# Altium schematic sheet 01-Power.SchDoc(Power)
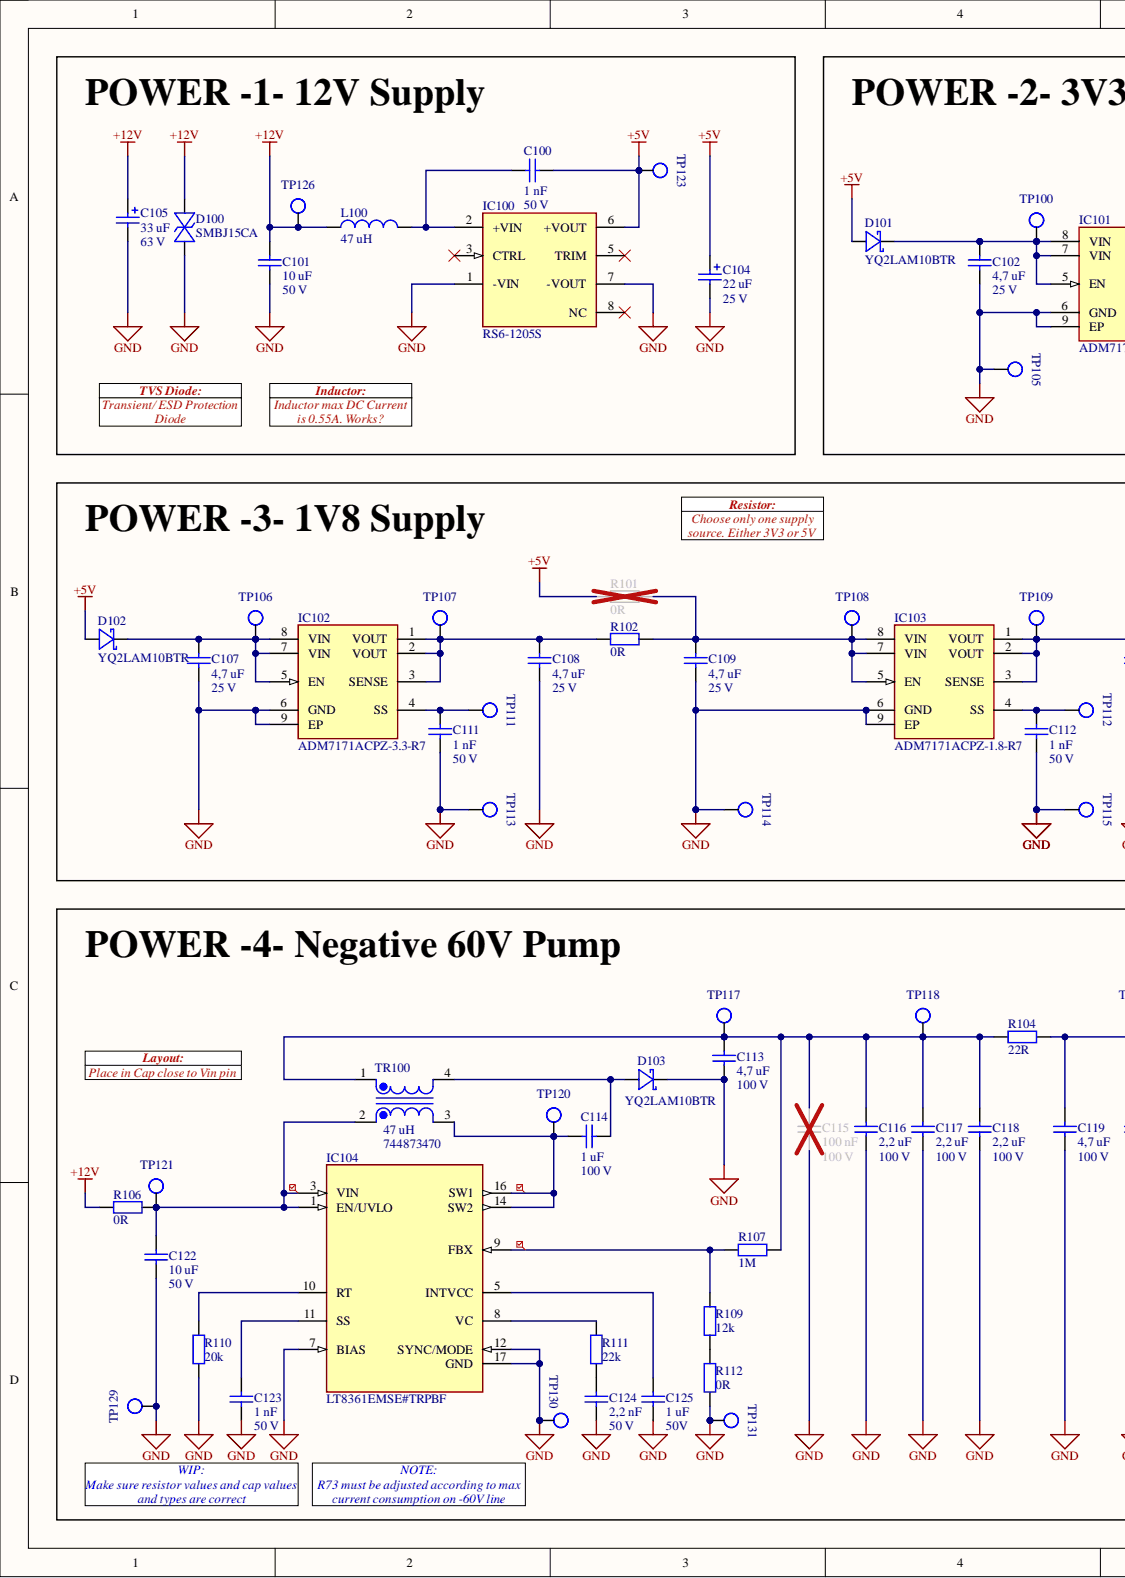
[diagram p0: 01-Power.SchDoc, left]
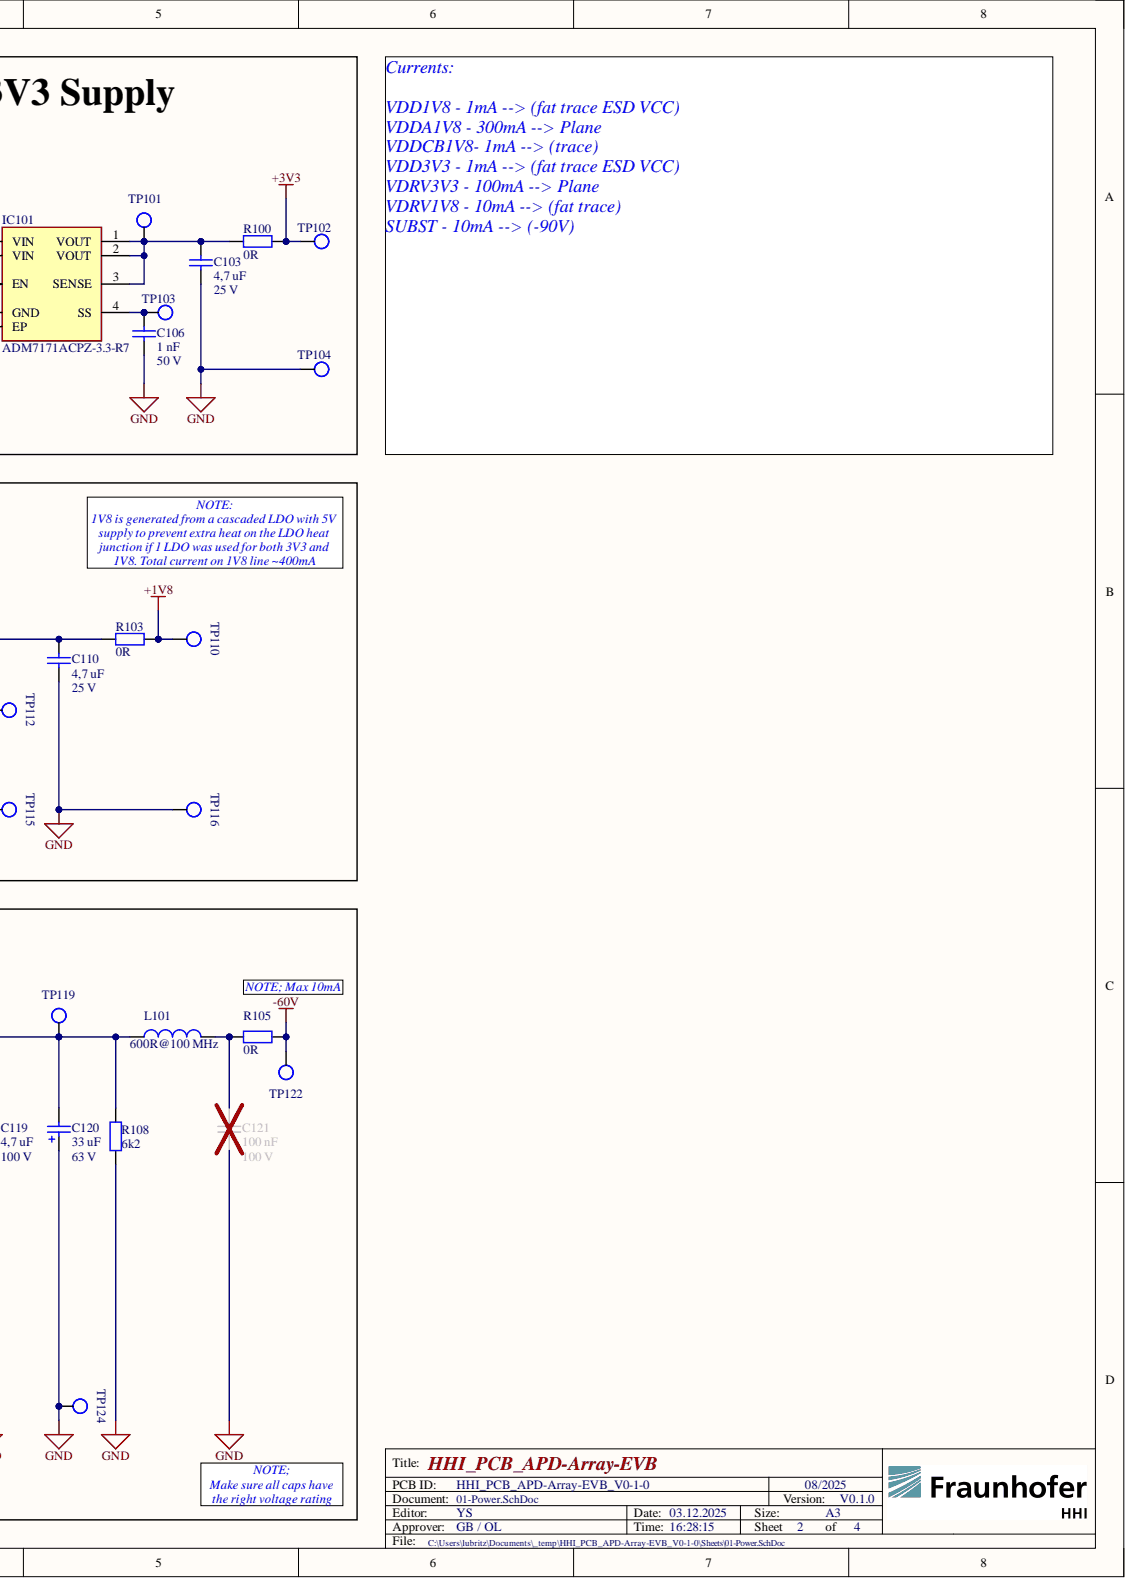
[diagram p1: 01-Power.SchDoc, right]

## Parts
C100  1 nF  [CAP_CAPC1608_0603_N]
C101  10 uF  Voltage: 50 V  [CAP_CAPC2012_0805_N]
C102  4,7 uF  Voltage: 25 V  [CAP_CAPC1608_0603_N]
C103  4,7 uF  Voltage: 25 V  [CAP_CAPC1608_0603_N]
C104  22 uF  Voltage: 25 V  [CAP_Elko_TypC_5,3x5,3x5,8]
C105  33 uF  [CAP_Elko_TypF_8,3x8,3x10,2]
C106  1 nF  Voltage: 50 V  [CAP_CAPC1608_0603_N]
C107  4,7 uF  Voltage: 25 V  [CAP_CAPC1608_0603_N]
C108  4,7 uF  Voltage: 25 V  [CAP_CAPC1608_0603_N]
C109  4,7 uF  Voltage: 25 V  [CAP_CAPC1608_0603_N]
C110  4,7 uF  Voltage: 25 V  [CAP_CAPC1608_0603_N]
C111  1 nF  Voltage: 50 V  [CAP_CAPC1608_0603_N]
C112  1 nF  Voltage: 50 V  [CAP_CAPC1608_0603_N]
C113  4,7 uF  Voltage: 100 V  [CAP_CAPC3216_1206_N]
C114  1 uF  Voltage: 100 V  [CAP_CAPC4532_1812]
C115  100 nF  [CAP_CAPC1608_0603_N]
C116  2,2 uF  Voltage: 100 V  [CAP_CAPC3225_1210_N]
C117  2,2 uF  Voltage: 100 V  [CAP_CAPC3225_1210_N]
C118  2,2 uF  Voltage: 100 V  [CAP_CAPC3225_1210_N]
C119  4,7 uF  Voltage: 100 V  [CAP_CAPC3216_1206_N]
C120  33 uF  Voltage: 63 V  [CAP_Elko_TypF_8,3x8,3x10,2]
C121  100 nF  Voltage: 100 V  [CAP_CAPC1608_0603_N]
C122  10 uF  Voltage: 50 V  [CAP_CAPC2012_0805_N]
C123  1 nF  Voltage: 50 V  [CAP_CAPC1608_0603_N]
C124  2,2 nF  [CAP_CAPC1608_0603_N]
C125  1 uF  Voltage: 50V  [CAP_CAPC2012_0805_N]
D100  SMBJ15CA  [DIO_DO-214AA_SMB_DIOM4336X24_N]
D101  YQ2LAM10BTR  [DIO_SOD-128_SODFL470X95-2N]
D102  YQ2LAM10BTR  [DIO_SOD-128_SODFL470X95-2N]
D103  YQ2LAM10BTR  [DIO_SOD-128_SODFL470X95-2N]
IC100  RS6-1205S  OutputVoltage: 5 V  [IC_THT_RS6-1205S]
IC101  ADM7171ACPZ-3.3-R7  [IC_LFCSP-8_QFN50P300X300X80_HS-9N]
IC102  ADM7171ACPZ-3.3-R7  [IC_LFCSP-8_QFN50P300X300X80_HS-9N]
IC103  ADM7171ACPZ-1.8-R7  [IC_LFCSP-8_QFN50P300X300X80_HS-9N]
IC104  LT8361EMSE#TRPBF  [IC_MSOP-16_SOP50P490X110-17N_LT8361EMSE#TRPBF]
L100  47 uH  [IND_WE-MAPI_3015]
L101  600R@100 MHz  [IND_INDC1608_0603_N]
R100  0R  [RES_RESC3216_1206_N]
R101  0R  [RES_RESC3216_1206_N]
R102  0R  [RES_RESC3216_1206_N]
R103  0R  [RES_RESC3216_1206_N]
R104  22R  [RES_RESC1608_0603_N]
R105  0R  [RES_RESC1608_0603_N]
R106  0R  [RES_RESC1608_0603_N]
R107  1M  [RES_RESC1608_0603_N]
R108  6k2  [RES_RESC2012_0805_N]
R109  12k  [RES_RESC1608_0603_N]
R110  20k  [RES_RESC1608_0603_N]
R111  22k  [RES_RESC1608_0603_N]
R112  0R  [RES_RESC1608_0603_N]
TP100  0,8 mm / 1,4 mm  [MISC_TP_THT_RD_0,8MM_1,4MM]  (value not drawn)  (no BOM)
TP101  0,8 mm / 1,4 mm  [MISC_TP_THT_RD_0,8MM_1,4MM]  (value not drawn)  (no BOM)
TP102  0,8 mm / 1,4 mm  [MISC_TP_THT_RD_0,8MM_1,4MM]  (value not drawn)  (no BOM)
TP103  0,8 mm / 1,4 mm  [MISC_TP_THT_RD_0,8MM_1,4MM]  (value not drawn)  (no BOM)
TP104  0,8 mm / 1,4 mm  [MISC_TP_THT_RD_0,8MM_1,4MM]  (value not drawn)  (no BOM)
TP105  0,8 mm / 1,4 mm  [MISC_TP_THT_RD_0,8MM_1,4MM]  (value not drawn)  (no BOM)
TP106  0,8 mm / 1,4 mm  [MISC_TP_THT_RD_0,8MM_1,4MM]  (value not drawn)  (no BOM)
TP107  0,8 mm / 1,4 mm  [MISC_TP_THT_RD_0,8MM_1,4MM]  (value not drawn)  (no BOM)
TP108  0,8 mm / 1,4 mm  [MISC_TP_THT_RD_0,8MM_1,4MM]  (value not drawn)  (no BOM)
TP109  0,8 mm / 1,4 mm  [MISC_TP_THT_RD_0,8MM_1,4MM]  (value not drawn)  (no BOM)
TP110  0,8 mm / 1,4 mm  [MISC_TP_THT_RD_0,8MM_1,4MM]  (value not drawn)  (no BOM)
TP111  0,8 mm / 1,4 mm  [MISC_TP_THT_RD_0,8MM_1,4MM]  (value not drawn)  (no BOM)
TP112  0,8 mm / 1,4 mm  [MISC_TP_THT_RD_0,8MM_1,4MM]  (value not drawn)  (no BOM)
TP113  0,8 mm / 1,4 mm  [MISC_TP_THT_RD_0,8MM_1,4MM]  (value not drawn)  (no BOM)
TP114  0,8 mm / 1,4 mm  [MISC_TP_THT_RD_0,8MM_1,4MM]  (value not drawn)  (no BOM)
TP115  0,8 mm / 1,4 mm  [MISC_TP_THT_RD_0,8MM_1,4MM]  (value not drawn)  (no BOM)
TP116  0,8 mm / 1,4 mm  [MISC_TP_THT_RD_0,8MM_1,4MM]  (value not drawn)  (no BOM)
TP117  0,8 mm / 1,4 mm  [MISC_TP_THT_RD_0,8MM_1,4MM]  (value not drawn)  (no BOM)
TP118  0,8 mm / 1,4 mm  [MISC_TP_THT_RD_0,8MM_1,4MM]  (value not drawn)  (no BOM)
TP119  0,8 mm / 1,4 mm  [MISC_TP_THT_RD_0,8MM_1,4MM]  (value not drawn)  (no BOM)
TP120  0,8 mm / 1,4 mm  [MISC_TP_THT_RD_0,8MM_1,4MM]  (value not drawn)  (no BOM)
TP121  0,8 mm / 1,4 mm  [MISC_TP_THT_RD_0,8MM_1,4MM]  (value not drawn)  (no BOM)
TP122  0,8 mm / 1,4 mm  [MISC_TP_THT_RD_0,8MM_1,4MM]  (value not drawn)  (no BOM)
TP123  0,8 mm / 1,4 mm  [MISC_TP_THT_RD_0,8MM_1,4MM]  (value not drawn)  (no BOM)
TP124  0,8 mm / 1,4 mm  [MISC_TP_THT_RD_0,8MM_1,4MM]  (value not drawn)  (no BOM)
TP126  0,8 mm / 1,4 mm  [MISC_TP_THT_RD_0,8MM_1,4MM]  (value not drawn)  (no BOM)
TP129  0,8 mm / 1,4 mm  [MISC_TP_THT_RD_0,8MM_1,4MM]  (value not drawn)  (no BOM)
TP130  0,8 mm / 1,4 mm  [MISC_TP_THT_RD_0,8MM_1,4MM]  (value not drawn)  (no BOM)
TP131  0,8 mm / 1,4 mm  [MISC_TP_THT_RD_0,8MM_1,4MM]  (value not drawn)  (no BOM)
TR100  47 uH  MfrNo: 744873470  [IND_WE-DD_1280]

## Nets
+1V8: R103.2 TP110.1
+3V3: R100.2 TP102.1
+5V: C100.2 C104.1 D101.2 D102.2 IC100.6(+VOUT) R101.1 TP123.1
+12V: C101.1 C105.1 D100.2 L100.1 R106.1 TP126.1
-60V: R105.2 TP122.1
GND: C101.2 C102.2 C103.2 C104.2 C105.2 C106.2 C107.2 C108.2 C109.2 C110.2 C111.2 C112.2 C113.2 C115.2 C116.2 C117.2 C118.2 C119.2 C120.1 C121.2 C122.2 C123.2 C124.2 C125.2 D100.1 D103.1 IC100.1(-VIN) IC100.7(-VOUT) IC101.6(GND) IC101.9(EP) IC102.6(GND) IC102.9(EP) IC103.6(GND) IC103.9(EP) IC104.7(BIAS) IC104.12(SYNC/MODE) IC104.17(GND) R108.1 R110.1 R112.1 TP104.1 TP105.1 TP113.1 TP114.1 TP115.1 TP116.1 TP124.1 TP129.1 TP130.1 TP131.1
NetC100_1: C100.1 IC100.2(+VIN) L100.2
NetC102_1: C102.1 D101.1 IC101.5(EN) IC101.7(VIN) IC101.8(VIN) TP100.1
NetC103_1: C103.1 IC101.1(VOUT) IC101.2(VOUT) IC101.3(SENSE) R100.1 TP101.1
NetC106_1: C106.1 IC101.4(SS) TP103.1
NetC107_1: C107.1 D102.1 IC102.5(EN) IC102.7(VIN) IC102.8(VIN) TP106.1
NetC108_1: C108.1 IC102.1(VOUT) IC102.2(VOUT) IC102.3(SENSE) R102.1 TP107.1
NetC109_1: C109.1 IC103.5(EN) IC103.7(VIN) IC103.8(VIN) R101.2 R102.2 TP108.1
NetC110_1: C110.1 IC103.1(VOUT) IC103.2(VOUT) IC103.3(SENSE) R103.1 TP109.1
NetC111_1: C111.1 IC102.4(SS) TP111.1
NetC112_1: C112.1 IC103.4(SS) TP112.1
NetC113_1: C113.1 C115.1 C116.1 C117.1 C118.1 R104.1 R107.2 TP117.1 TP118.1 TR100.1
NetC114_1: C114.1 D103.2 TR100.4
NetC114_2: C114.2 IC104.14(SW2) IC104.16(SW1) TP120.1 TR100.3
NetC119_1: C119.1 C120.2 L101.1 R104.2 R108.2 TP119.1
NetC121_1: C121.1 L101.2 R105.1
NetC122_1: C122.1 IC104.1(EN/UVLO) IC104.3(VIN) R106.2 TP121.1 TR100.2
NetC123_1: C123.1 IC104.11(SS)
NetC124_1: C124.1 R111.1
NetC125_1: C125.1 IC104.5(INTVCC)
NetIC100_3: IC100.3(CTRL)
NetIC100_5: IC100.5(TRIM)
NetIC100_8: IC100.8(NC)
NetIC104_8: IC104.8(VC) R111.2
NetIC104_9: IC104.9(FBX) R107.1 R109.2
NetIC104_10: IC104.10(RT) R110.2
NetR109_1: R109.1 R112.2

## Library data of the parts
C100 = CAP0058: CAP / 1 nF / 0603 / 50 V / X7R / 10%; Mfr: Würth Elektronik; MfrNo: 885012206083; Database Table Name: capacitor$; Dis: Mouser; DisNo: 710-885012206083; Editor: OL; ProvidedBy: EMS; Type: MLCC; Voltage: 50 V; Component Kind: Standard; DesignItemID: CAP0058; Dielectric: X7R; ESR: -; Mfr-Link: https://www.we-online.com/de/components/products/WCAP-CSGP-50VDC; Package: 0603; Pins: 2; Status: Done; TempHigh: 125 °C; TempLow: -55 °C; Tolerance: 10%; Value: 1 nF; Library Name: Altium-Lib-HHI.DbLib
C101 C122 = CAP0067: CAP / 10 uF / 0805 / 50 V / X5R / 10%; Mfr: Murata; MfrNo: GRM21BR61H106KE43L; Database Table Name: capacitor$; Dis: Mouser; DisNo: 81-GRM21BR61H106KE3L; Editor: OL; ProvidedBy: EMS; Type: MLCC; Component Kind: Standard; DesignItemID: CAP0067; Dielectric: X5R; ESR: -; Mfr-Link: https://www.murata.com/en-eu/products/productdetail?partno=GRM21BR61H106KE43%23; Package: 0805; Pins: 2; Status: Done; TempHigh: 85 °C; TempLow: -55 °C; Tolerance: 10%; Value: 10 uF; Library Name: Altium-Lib-HHI.DbLib
C102 C103 C107-C110 = CAP0043: CAP / 4,7 uF / 0603 / 25 V / X5R / 10%; Mfr: Murata; MfrNo: GRM188R61E475KE11D; Database Table Name: capacitor$; Dis: Mouser; DisNo: 81-GRM188R61E475KE1D; Editor: OL; ProvidedBy: EMS; Type: MLCC; Component Kind: Standard; DesignItemID: CAP0043; Dielectric: X5R; ESR: -; Mfr-Link: https://www.murata.com/en-eu/products/productdetail?partno=GRM188R61E475KE11%23; Package: 0603; Pins: 2; Status: Done; TempHigh: 85 °C; TempLow: -55 °C; Tolerance: 10%; Value: 4,7 uF; Library Name: Altium-Lib-HHI.DbLib
C104 = CAP0112: CAP / 22 uF / 5,3x5,3x5,8 / 25 V / - / 20%; Mfr: Panasonic; MfrNo: EEEFP1E220AR; Database Table Name: capacitor$; Dis: Mouser; DisNo: 667-EEE-FP1E220AR; Editor: OL; ESR: 360 mR; ProvidedBy: EMS; Type: Al-Elko; Component Kind: Standard; DesignItemID: CAP0112; Dielectric: -; Mfr-Link: https://industrial.panasonic.com/ww/products/pt/aluminum-cap-smd/models/EEEFP1E220AR; Package: 5,3x5,3x5,8; Pins: 2; Status: Done; TempHigh: 105 °C; TempLow: -55 °C; Tolerance: 20%; Value: 22 uF; Library Name: Altium-Lib-HHI.DbLib
C105 = CAP0038: CAP / 33 uF / 8,3x8,3x10,2 / 63 V / - / 20%; Mfr: Panasonic; MfrNo: EEEFK1J330P; Database Table Name: capacitor$; Dis: Mouser; DisNo: 67-EEE-FK1J330P; Editor: OL; ESR: 650 mR; ProvidedBy: EMS; Type: Al-Elko; Voltage: 63 V; Component Kind: Standard; DesignItemID: CAP0038; Dielectric: -; Mfr-Link: https://industrial.panasonic.com/ww/products/pt/aluminum-cap-smd/models/EEEFK1J330P; Package: 8,3x8,3x10,2; Pins: 2; Status: Done; TempHigh: 105 °C; TempLow: -55 °C; Tolerance: 20%; Value: 33 uF; Library Name: Altium-Lib-HHI.DbLib
C106 C111 C112 C123 = CAP0058: CAP / 1 nF / 0603 / 50 V / X7R / 10%; Mfr: Würth Elektronik; MfrNo: 885012206083; Database Table Name: capacitor$; Dis: Mouser; DisNo: 710-885012206083; Editor: OL; ProvidedBy: EMS; Type: MLCC; Component Kind: Standard; DesignItemID: CAP0058; Dielectric: X7R; ESR: -; Mfr-Link: https://www.we-online.com/de/components/products/WCAP-CSGP-50VDC; Package: 0603; Pins: 2; Status: Done; TempHigh: 125 °C; TempLow: -55 °C; Tolerance: 10%; Value: 1 nF; Library Name: Altium-Lib-HHI.DbLib
C113 C119 = CAP0118: CAP / 4,7 uF / 1206 / 100 V / X7R / 10%; Mfr: TDK; MfrNo: C3216X7R2A475K160AC; Database Table Name: capacitor$; Dis: Mouser; DisNo: 810-C3216X7R2475K160; Editor: OL; ProvidedBy: EMS; Type: MLCC; Component Kind: Standard; DesignItemID: CAP0118; Dielectric: X7R; ESR: -; Mfr-Link: https://product.tdk.com/de/search/capacitor/ceramic/mlcc/info?part_no=C3216X7R2A475K160AC; Package: 1206; Pins: 2; Status: Done; TempHigh: 125 °C; TempLow: -55 °C; Tolerance: 10%; Value: 4,7 uF; Library Name: Altium-Lib-HHI.DbLib
C114 = CAP0065: CAP / 1 uF / 1812 / 100 V / X7R / 10%; Mfr: Kyocera AVX; MfrNo: 18121C105KAT2A; Database Table Name: capacitor$; Dis: Mouser; DisNo: 581-18121C105K; Editor: OL; ProvidedBy: EMS; Type: MLCC; Component Kind: Standard; DesignItemID: CAP0065; Dielectric: X7R; ESR: -; Mfr-Link: https://www.kyocera-avx.com/products/ceramic-capacitors/surface-mount/x7r-dielectric/; Package: 1812; Pins: 2; Status: Done; TempHigh: 125 °C; TempLow: -55 °C; Tolerance: 10%; Value: 1 uF; Library Name: Altium-Lib-HHI.DbLib
C115 = CAP0004: CAP / 100 nF / 0603 / 100 V / X7R / 10%; Mfr: Würth Elektronik; MfrNo: 885012206120; Database Table Name: capacitor$; Dis: Mouser; DisNo: 710-885012206120; Editor: OL; ProvidedBy: EMS; Type: MLCC; Voltage: 100 V; Component Kind: Standard; DesignItemID: CAP0004; Dielectric: X7R; ESR: -; Mfr-Link: https://www.we-online.com/de/components/products/WCAP-CSGP-100VDC; Package: 0603; Pins: 2; Status: Done; TempHigh: 125 °C; TempLow: -55 °C; Tolerance: 10%; Value: 100 nF; Library Name: Altium-Lib-HHI.DbLib
C116-C118 = CAP0035: CAP / 2,2 uF / 1210 / 100 V / X7R / 10%; Mfr: TDK; MfrNo: C3225X7R2A225K230AB; Database Table Name: capacitor$; Dis: Mouser; DisNo: 810-C3225X7R2A225K; Editor: OL; ProvidedBy: EMS; Type: MLCC; Component Kind: Standard; DesignItemID: CAP0035; Dielectric: X7R; ESR: -; Mfr-Link: https://product.tdk.com/de/search/capacitor/ceramic/mlcc/info?part_no=C3225X7R2A225K230AB; Package: 1210; Pins: 2; Status: Done; TempHigh: 125 °C; TempLow: -55 °C; Tolerance: 10%; Value: 2,2 uF; Library Name: Altium-Lib-HHI.DbLib
C120 = CAP0038: CAP / 33 uF / 8,3x8,3x10,2 / 63 V / - / 20%; Mfr: Panasonic; MfrNo: EEEFK1J330P; Database Table Name: capacitor$; Dis: Mouser; DisNo: 67-EEE-FK1J330P; Editor: OL; ESR: 650 mR; ProvidedBy: EMS; Type: Al-Elko; Component Kind: Standard; DesignItemID: CAP0038; Dielectric: -; Mfr-Link: https://industrial.panasonic.com/ww/products/pt/aluminum-cap-smd/models/EEEFK1J330P; Package: 8,3x8,3x10,2; Pins: 2; Status: Done; TempHigh: 105 °C; TempLow: -55 °C; Tolerance: 20%; Value: 33 uF; Library Name: Altium-Lib-HHI.DbLib
C121 = CAP0004: CAP / 100 nF / 0603 / 100 V / X7R / 10%; Mfr: Würth Elektronik; MfrNo: 885012206120; Database Table Name: capacitor$; Dis: Mouser; DisNo: 710-885012206120; Editor: OL; ProvidedBy: EMS; Type: MLCC; Component Kind: Standard; DesignItemID: CAP0004; Dielectric: X7R; ESR: -; Mfr-Link: https://www.we-online.com/de/components/products/WCAP-CSGP-100VDC; Package: 0603; Pins: 2; Status: Done; TempHigh: 125 °C; TempLow: -55 °C; Tolerance: 10%; Value: 100 nF; Library Name: Altium-Lib-HHI.DbLib
C124 = CAP0069: CAP / 2,2 nF / 0603 / 50 V / X7R / 10%; Mfr: Würth Elektronik; MfrNo: 885012206085; Database Table Name: capacitor$; Dis: Mouser; DisNo: 710-885012206085; Editor: OL; ProvidedBy: EMS; Type: MLCC; Voltage: 50 V; Component Kind: Standard; DesignItemID: CAP0069; Dielectric: X7R; ESR: -; Mfr-Link: https://www.we-online.com/de/components/products/WCAP-CSGP-50VDC?sq=885012206085#885012206085; Package: 0603; Pins: 2; Status: Done; TempHigh: 125 °C; TempLow: -55 °C; Tolerance: 10%; Value: 2,2 nF; Library Name: Altium-Lib-HHI.DbLib
C125 = CAP0114: CAP / 1 uF / 0805 / 50V / X7R  / 5%; Mfr: KEMET; MfrNo: C0805X105J5RACAUTO; Database Table Name: capacitor$; Dis: Mouser; DisNo: 80-C0805X105J5RAUTO; Editor: OL; ProvidedBy: EMS; Type: MLCC; Component Kind: Standard; DesignItemID: CAP0114; Dielectric: X7R; ESR: -; Mfr-Link: https://www.yageogroup.com/products/Capacitors/part/C0805X105J5RACAUTO; Package: 0805; Pins: 2; Status: Done; TempHigh: 125 °C; TempLow: -55 °C; Tolerance: 5%; Value: 1 uF; Library Name: Altium-Lib-HHI.DbLib
D100 = DIODE0004: DIODE / TVS-BI / SMBJ15CA / 15 V / 15 V / 600 W; Mfr: Bourns; Breakdown Voltage: 15 V; Database Table Name: diode$; Dis: Mouser; DisNo: 652-SMBJ15CA; Editor: OL; Power: 600 W; ProvidedBy: EMS; Component Kind: Standard; Current: -; DesignItemID: DIODE0004; Mfr-Link: https://www.bourns.com/docs/product-datasheets/smbj.pdf; MfrNo: SMBJ15CA; Package: DO-214AA / SMB; Pins: 2; Status: Done; TempHigh: 150 °C; TempLow: -55 °C; Type: TVS-BI; Value: SMBJ15CA; Voltage: 15 V; Library Name: Altium-Lib-HHI.DbLib
D101-D103 = DIODE0014: DIODE / schottky / YQ2LAM10BTR / 0,6 V / 100 V / -; Mfr: Rohm; Breakdown Voltage: 100 V; Current: 2 A; Database Table Name: diode$; Dis: Mouser; DisNo: 755-YQ2LAM10BTR; Editor: YS; ProvidedBy: EMS; Voltage: 0,6 V; Component Kind: Standard; DesignItemID: DIODE0014; Mfr-Link: https://www.rohm.de/products/diodes/schottky-barrier-diodes/high-efficiency/yq2lam10b-product; MfrNo: YQ2LAM10BTR; Package: SOD-128; Pins: 2; Power: -; Status: InProgress; TempHigh: 175 °C; TempLow: -55 °C; Type: schottky; Value: YQ2LAM10BTR; Library Name: Altium-Lib-HHI.DbLib
IC100 = IC0031: IC / power / RS6-1205S / Step-Down DCDC; Mfr: RECOM Power; Database Table Name: ic$; Dis: Mouser; DisNo: 919-RS6-1205S; Editor: OL; Frequency: 200 kHz; InputVoltage: 9 - 18 V; MaxCurrent: 1,2 A; ProvidedBy: HHI; AdditionalDescription: Step-Down DCDC; Component Kind: Standard; DesignItemID: IC0031; Library Name: Altium-Lib-HHI.DbLib; Memory: -; Mfr-Link: https://recom-power.com/de/products/dc-dc-converters/dc-dc-converters-regulated/rec-s-RS6.html?4; MfrNo: RS6-1205S; Package: THT; Pins: 8; SensorType: -; Status: Done; Supply Voltage: -; TempHigh: 75  °C; TempLow: -40  °C; Type: power; Value: RS6-1205S
IC101 IC102 = IC0023: IC / power / ADM7171ACPZ-3.3-R7 / LDO; Mfr: Analog Devices; Database Table Name: ic$; Dis: Mouser; DisNo: 584-ADM7171ACPZ3.3R7; Editor: OL; InputVoltage: 2,3 - 6,5 V; MaxCurrent: 1 A; OutputVoltage: 3,3V; ProvidedBy: EMS; AdditionalDescription: LDO; Component Kind: Standard; DesignItemID: IC0023; Frequency: -; Memory: -; Mfr-Link: https://www.analog.com/en/products/adm7171.html; MfrNo: ADM7171ACPZ-3.3-R7; Package: LFCSP-8; Pins: 8; SensorType: -; Status: Done; Supply Voltage: -; TempHigh: 125  °C; TempLow: -40  °C; Type: power; Value: ADM7171ACPZ-3.3-R7; Library Name: Altium-Lib-HHI.DbLib
IC103 = IC0024: IC / power / ADM7171ACPZ-1.8-R7 / LDO; Mfr: Analog Devices; Database Table Name: ic$; Dis: Mouser; DisNo: 584-ADM7171ACPZ1.8R7; Editor: OL; InputVoltage: 2,3 - 6,5 V; MaxCurrent: 1 A; OutputVoltage: 1,8 V; ProvidedBy: EMS; AdditionalDescription: LDO; Component Kind: Standard; DesignItemID: IC0024; Frequency: -; Memory: -; Mfr-Link: https://www.analog.com/en/products/adm7171.html; MfrNo: ADM7171ACPZ-1.8-R7; Package: LFCSP-8; Pins: 8; SensorType: -; Status: Done; Supply Voltage: -; TempHigh: 125  °C; TempLow: -40  °C; Type: power; Value: ADM7171ACPZ-1.8-R7; Library Name: Altium-Lib-HHI.DbLib
IC104 = IC0036: IC / power / LT8361EMSE#TRPBF / SEPIC/Boost/Inverting DCDC; Mfr: Analog Devices; Database Table Name: ic$; Dis: Mouser; DisNo: 584-LT8361EMSE#TRPBF; Editor: YS; Frequency: 300 kHz - 2 MHz; InputVoltage: 2,8V - 60V; MaxCurrent: 2 A; OutputVoltage: ±0 - 100 V; ProvidedBy: EMS; AdditionalDescription: SEPIC/Boost/Inverting DCDC; Component Kind: Standard; DesignItemID: IC0036; Memory: -; Mfr-Link: https://www.analog.com/en/products/lt8361.html#overview; MfrNo: LT8361EMSE#TRPBF; Package: MSOP-16; Pins: 16; SensorType: -; Status: Done; Supply Voltage: -; TempHigh: 125  °C; TempLow: -40  °C; Type: power; Value: LT8361EMSE#TRPBF; Library Name: Altium-Lib-HHI.DbLib
L100 = IND0039: inductor / 47 uH / 3,0x3,0x1,5 / 0,55 A / 8 MHz; Mfr: Würth Elektronik; MfrNo: 74438335470; Current: 0,55 A; Database Table Name: inductor$; DCResistance: 2300 mR; Dis: Mouser; DisNo: 710-74438335470; Editor: OL; Frequency: 8 MHz; ProvidedBy: EMS; SaturationCurrent: 0,75 A; Tolerance: 20%; Voltage: 80 V; Component Kind: Standard; DesignItemID: IND0039; Mfr-Link: https://www.we-online.com/en/components/products/WE-MAPI?sq=74438335470#74438335470; Package: 3,0x3,0x1,5; Pins: 2; Ratio: -; Shielded: shielded; Status: Done; TempHigh: 125 °C; TempLow: -40 °C; Type: inductor; Value: 47 uH; Library Name: Altium-Lib-HHI.DbLib
L101 = IND0044: ferrit / 600R / 0603 / 0,45 A / 100 MHz; Mfr: Murata; MfrNo: BLM18DN601SZ1D; Current: 0,45 A; Database Table Name: inductor$; DCResistance: 435mR; Dis: Mouser; DisNo: 81-BLM18DN601SZ1D; Editor: GB; Frequency: 100 MHz; ProvidedBy: EMS; Shielded: unshielded; Tolerance: 25%; Component Kind: Standard; DesignItemID: IND0044; Mfr-Link: https://pim.murata.com/en-eu/pim/details/?partNum=BLM18DN601SZ1%23; Package: 0603; Pins: 2; Ratio: -; SaturationCurrent: -; Status: Done; TempHigh: 125°C; TempLow: -55°C; Type: ferrit; Value: 600R; Voltage: -; Library Name: Altium-Lib-HHI.DbLib
R100-R103 = RES0085: RES / 0R / 1206 / 1% / 200 V / 250 mW; Mfr: YAGEO; MfrNo: RC1206FR-100RL; Database Table Name: resistor$; Dis: Mouser; DisNo: 603-RC1206FR-100RL; Editor: OL; Power: 250 mW; ProvidedBy: EMS; Voltage: 200 V; Component Kind: Standard; DesignItemID: RES0085; Mfr-Link: https://www.yageo.com/en/Product/Index/rchip/thick_film_general_purpose; Package: 1206; Pins: 2; Status: Done; TempHigh: 155 °C; TempLow: -55 °C; Tolerance: 1%; Type: jumper; Value: 0R; Library Name: Altium-Lib-HHI.DbLib
R104 = RES0154: RES / 22R / 0603 / 0.5% / 150 V / 400 mW; Mfr: ROHM; MfrNo: SDR03EZPD22R0; Database Table Name: resistor$; Dis: Mouser; DisNo: 755-SDR03EZPD22R0; Editor: OL; Power: 400 mW; ProvidedBy: EMS; Voltage: 150 V; Component Kind: Standard; DesignItemID: RES0154; Mfr-Link: https://www.rohm.de/products/resistors/high-reliability-resistors/high-anti-surge-chip-resistors/sdr03ezpd-product; Package: 0603; Pins: 2; Status: Done; TempHigh: 155 °C; TempLow: -55 °C; Tolerance: 0.5%; Type: resistor; Value: 22R; Library Name: Altium-Lib-HHI.DbLib
R105 R106 = RES0072: RES / 0R / 0603 / < 20mR / 75 V / 210 mW; Mfr: Vishay; MfrNo: MCT0603MZ0000ZP500; Database Table Name: resistor$; Dis: Mouser; DisNo: 594-MCT0603MZ0000ZP5; Editor: OL; Power: 210 mW; ProvidedBy: EMS; Tolerance: < 20mR; Voltage: 75 V; Component Kind: Standard; DesignItemID: RES0072; Mfr-Link: https://www.vishay.com/en/product/28952/; Package: 0603; Pins: 2; Status: Done; TempHigh: 175 °C; TempLow: -55 °C; Type: jumper; Value: 0R; Library Name: Altium-Lib-HHI.DbLib
R107 = RES0156: RES / 1M / 0603 / 1% / 75 V / 100 mW; Mfr: YAGEO; MfrNo: RC0603FR-071ML; Database Table Name: resistor$; Dis: Mouser; DisNo: 603-RC0603FR-071ML; Editor: OL; Power: 100 mW; ProvidedBy: EMS; Voltage: 75 V; Component Kind: Standard; DesignItemID: RES0156; Mfr-Link: https://www.yageo.com/upload/media/product/productsearch/datasheet/rchip/PYu-RC_51_RoHS_P_6.pdf; Package: 0603; Pins: 2; Status: Done; TempHigh: 155 °C; TempLow: -55 °C; Tolerance: 1%; Type: resistor; Value: 1M; Library Name: Altium-Lib-HHI.DbLib
R108 = RES0151: RES / 6k2 / 0805 / 1% / 150 V / 500 mW; Mfr: Vishay; MfrNo: CRCW08056K20FKEAHP; Database Table Name: resistor$; Dis: Mouser; DisNo: 71-CRCW08056K20FKEAH; Editor: OL; Power: 500 mW; ProvidedBy: EMS; Voltage: 150 V; Component Kind: Standard; DesignItemID: RES0151; Mfr-Link: https://www.vishay.com/en/product/20043/; Package: 0805; Pins: 2; Status: Done; TempHigh: 155 °C; TempLow: -55 °C; Tolerance: 1%; Type: resistor; Value: 6k2; Library Name: Altium-Lib-HHI.DbLib
R109 = RES0111: RES / 12k / 0603 / 1% / 75 V / 100 mW; Mfr: YAGEO; MfrNo: RC0603FR-1012KL; Database Table Name: resistor$; Dis: Mouser; DisNo: 603-RC0603FR-1012KL; Editor: OL; Power: 100 mW; ProvidedBy: EMS; Voltage: 75 V; Component Kind: Standard; DesignItemID: RES0111; Mfr-Link: https://www.yageo.com/upload/media/product/productsearch/datasheet/rchip/PYu-RC_51_RoHS_P_6.pdf; Package: 0603; Pins: 2; Status: Done; TempHigh: 155 °C; TempLow: -55 °C; Tolerance: 1%; Type: resistor; Value: 12k; Library Name: Altium-Lib-HHI.DbLib
R110 = RES0074: RES / 20k / 0603 / 1% / 75 V / 100 mW; Mfr: YAGEO; MfrNo: RT0603FRE0720KL; Database Table Name: resistor$; Dis: Mouser; DisNo: 603-RT0603FRE0720KL; Editor: OL; Power: 100 mW; ProvidedBy: EMS; Voltage: 75 V; Component Kind: Standard; DesignItemID: RES0074; Mfr-Link: https://www.yageo.com/upload/media/product/productsearch/datasheet/rchip/PYu-RT_1-to-0.01_RoHS_L_15.pdf; Package: 0603; Pins: 2; Status: Done; TempHigh: 155 °C; TempLow: -55 °C; Tolerance: 1%; Type: resistor; Value: 20k; Library Name: Altium-Lib-HHI.DbLib
R111 = RES0150: RES / 22k / 0603 / 0,01 / 75 V / 100 mW; Mfr: YAGEO; MfrNo: RT0603FRE0722KL; Database Table Name: resistor$; Dis: Mouser; DisNo: 603-RT0603FRE0722KL; Editor: OL; Power: 100 mW; ProvidedBy: EMS; Tolerance: 1%; Voltage: 75 V; Component Kind: Standard; DesignItemID: RES0150; Mfr-Link: https://www.yageogroup.com/products/Resistors/part/RT0603FRE0722KL; Package: 0603; Pins: 2; Status: Done; TempHigh: 155 °C; TempLow: -55 °C; Type: resistor; Value: 22k; Library Name: Altium-Lib-HHI.DbLib
R112 = RES0006: RES / 0R / 0603 / 5% / 75 V / 100 mW; Mfr: YAGEO; MfrNo: RC0603JR-070RL; Database Table Name: resistor$; Dis: Mouser; DisNo: 603-RC0603JR-070RL; Editor: OL; Power: 100 mW; ProvidedBy: EMS; Voltage: 75 V; Component Kind: Standard; DesignItemID: RES0006; Mfr-Link: https://www.yageo.com/upload/media/product/productsearch/datasheet/rchip/PYu-RC_Group_51_RoHS_L_12.pdf; Package: 0603; Pins: 2; Status: Done; TempHigh: 155 °C; TempLow: -55 °C; Tolerance: 5%; Type: jumper; Value: 0R; Library Name: Altium-Lib-HHI.DbLib
TP100-TP124 TP126 TP129-TP131 = MISC0008: MISC / test-point / 0,8 mm / 1,4 mm / round / THT; Database Table Name: misc$; Editor: OL; AdditionalDescription: round / THT; DesignItemID: MISC0008; Dis: -; DisNo: -; Frequency: -; MaxCurrent: -; Mfr: -; Mfr-Link: -; MfrNo: -; Package: -; Pins: -; ProvidedBy: -; Status: Done; TempHigh: -; TempLow: -; Type: test-point; Value: 0,8 mm / 1,4 mm; Voltage: -; Library Name: Altium-Lib-HHI.DbLib
TR100 = IND0048: CMchoke / 47 uH / 12,5x12,5x8,5 / 2,02 A / 7,8 MHz; Mfr: Würth Elektronik; Current: 2,02 A; Database Table Name: inductor$; DCResistance: 120 mR; Dis: Mouser; DisNo: 710-744873470; Editor: YS; Frequency: 7,8 MHz; ProvidedBy: EMS; Ratio: 1:1; SaturationCurrent: 3,1 A; Tolerance: 20%; Voltage: 80 V; Component Kind: Standard; DesignItemID: IND0048; Mfr-Link: https://www.we-online.com/de/components/products/WE-DD; Package: 12,5x12,5x8,5; Pins: 4; Shielded: shielded; Status: InProgress; TempHigh: 125 °C; TempLow: -40 °C; Type: CMchoke; Value: 47 uH; Library Name: Altium-Lib-HHI.DbLib
